# Altium schematic sheet SensorMotherBoard.SchDoc(SensorMotherBoard)
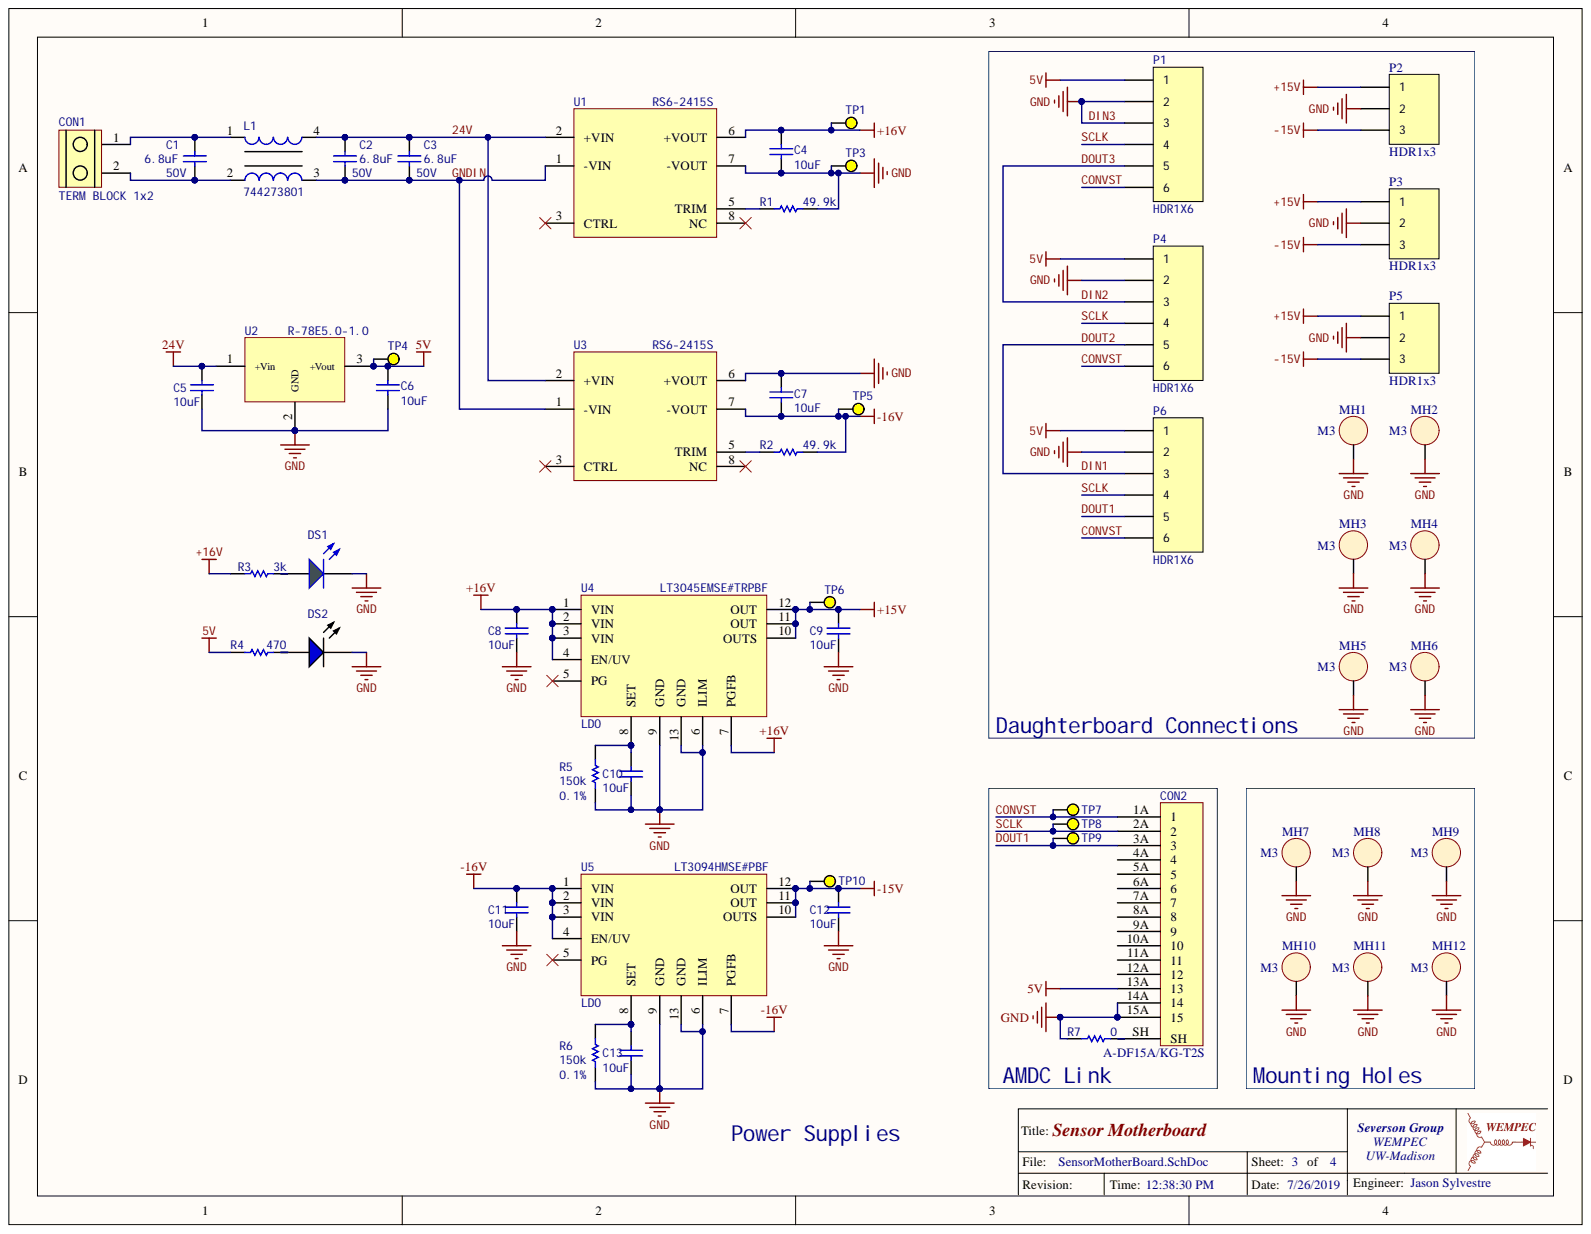
[diagram p0: SensorMotherBoard.SchDoc]

## Parts
*?  TitleBlockWEMPEC  (value not drawn)
C1  Voltage Rating (DC): 50V  Capacitance: 6.8uF  [CAP-1206]  (hidden comment: Cap)
C2  Voltage Rating (DC): 50V  Capacitance: 6.8uF  [CAP-1206]  (hidden comment: Cap)
C3  Voltage Rating (DC): 50V  Capacitance: 6.8uF  [CAP-1206]  (hidden comment: Cap)
C4  Capacitance: 10uF  [CAP-0805]  (hidden comment: Cap)
C5  Capacitance: 10uF  [CAP-0805]  (hidden comment: Cap)
C6  Capacitance: 10uF  [CAP-0805]  (hidden comment: Cap)
C7  Capacitance: 10uF  [CAP-0805]  (hidden comment: Cap)
C8  Capacitance: 10uF  [CAP-0805]  (hidden comment: Cap)
C9  Capacitance: 10uF  [CAP-0805]  (hidden comment: Cap)
C10  Capacitance: 10uF  [CAP-0805]  (hidden comment: Cap)
C11  Capacitance: 10uF  [CAP-0805]  (hidden comment: Cap)
C12  Capacitance: 10uF  [CAP-0805]  (hidden comment: Cap)
C13  Capacitance: 10uF  [CAP-0805]  (hidden comment: Cap)
CON1  TERM BLOCK 1x2  [1935161]
CON2  Manufacturer Part Number: A-DF15A/KG-T2S  Current Rating: 3A  [A-DF 15 A/KG-T2S]  (hidden comment: CON - A-DF 15 A/KG-T2S)
DS1  LTST-C193KGKT-5A  [LTST-C193KGKT-5A_V]  (value not drawn)
DS2  LTST-C193TBKT-5A  [LTST-C193TBKT_V]  (value not drawn)
L1  744273801  [WE-SL5-HC]
MH1  M3  [MOUNTING HOLE - M3]
MH2  M3  [MOUNTING HOLE - M3]
MH3  M3  [MOUNTING HOLE - M3]
MH4  M3  [MOUNTING HOLE - M3]
MH5  M3  [MOUNTING HOLE - M3]
MH6  M3  [MOUNTING HOLE - M3]
MH7  M3  [MOUNTING HOLE - M3]
MH8  M3  [MOUNTING HOLE - M3]
MH9  M3  [MOUNTING HOLE - M3]
MH10  M3  [MOUNTING HOLE - M3]
MH11  M3  [MOUNTING HOLE - M3]
MH12  M3  [MOUNTING HOLE - M3]
P1  HDR1X6  [HDR1x6 - MALE]
P2  HDR1x3  [HDR1x3 - MALE]
P3  HDR1x3  [HDR1x3 - MALE]
P4  HDR1X6  [HDR1x6 - MALE]
P5  HDR1x3  [HDR1x3 - MALE]
P6  HDR1X6  [HDR1x6 - MALE]
R1  Resistance: 49.9k  [RESC1608X55X30ML15T15]  (hidden comment: ERJ-3EKF4992V)
R2  Resistance: 49.9k  [RESC1608X55X30ML15T15]  (hidden comment: ERJ-3EKF4992V)
R3  Resistance: 3k  [RESC1608X55X30LL15T15]  (hidden comment: ERJ-3GEYJ302V)
R4  RC0603FR-07470RL  [RESC1608X55X25LL10T15]  (value not drawn)
R5  Tolerance: 0.1%  Resistance: 150k  [RESC1608X55X30LL20T20]  (hidden comment: ERA3AEB154V)
R6  Tolerance: 0.1%  Resistance: 150k  [RESC1608X55X30LL20T20]  (hidden comment: ERA3AEB154V)
R7  ERJ-3GEY0R00V  [RESC1608X55X30NL15T15]  (value not drawn)
TP1  5004  [KSTN-5004]  (value not drawn)
TP3  5004  [KSTN-5004]  (value not drawn)
TP4  5004  [KSTN-5004]  (value not drawn)
TP5  5004  [KSTN-5004]  (value not drawn)
TP6  5004  [KSTN-5004]  (value not drawn)
TP7  5004  [KSTN-5004]  (value not drawn)
TP8  5004  [KSTN-5004]  (value not drawn)
TP9  5004  [KSTN-5004]  (value not drawn)
TP10  5004  [KSTN-5004]  (value not drawn)
U1  Manufacturer Part Number: RS6-2415S  [RS6 DCDC]  (hidden comment: DCDC Converter RS6)
U2  DCDC - R-78E-1.0  [R-78E5.0-1.0]  (value not drawn)
U3  Manufacturer Part Number: RS6-2415S  [RS6 DCDC]  (hidden comment: DCDC Converter RS6)
U4  LDO  Manufacturer Part Number: LT3045EMSE#TRPBF  [MSOP-12]
U5  LDO  Manufacturer Part Number: LT3094HMSE#PBF  [MSOP-12]

## Nets
5V: C6.2 CON2.13A P1.1 P4.1 P6.1 R4.1 TP4.1 U2.3
+15V: C9.2 P2.1 P3.1 P5.1 TP6.1 U4.10(OUTS) U4.11(OUT) U4.12(OUT)
+16V: C4.2 C8.2 R3.1 TP1.1 U1.6(+VOUT) U4.1(VIN) U4.2(VIN) U4.3(VIN) U4.4(EN/UV) U4.7(PGFB)
-15V: C12.2 P2.3 P3.3 P5.3 TP10.1 U5.10(OUTS) U5.11(OUT) U5.12(OUT)
-16V: C7.1 C11.2 R2.2 TP5.1 U3.7(-VOUT) U5.1(VIN) U5.2(VIN) U5.3(VIN) U5.4(EN/UV) U5.7(PGFB)
24V: C2.2 C3.2 C5.2 L1.4 U1.2(+VIN) U2.1 U3.2(+VIN)
CONVST: CON2.1A P1.6 P4.6 P6.6 TP7.1
DIN1: P4.5 P6.3
DIN2: P1.5 P4.3
DIN3: C4.1 C5.1 C6.1 C7.2 C8.1 C9.1 C10.1 C11.1 C12.1 C13.1 CON2.14A CON2.15A DS1.2 DS2.2 MH1.1 MH2.1 MH3.1 MH4.1 MH5.1 MH6.1 MH7.1 MH8.1 MH9.1 MH10.1 MH11.1 MH12.1 P1.2 P1.3 P2.2 P3.2 P4.2 P5.2 P6.2 R1.2 R5.1 R6.1 R7.1 TP3.1 U1.7(-VOUT) U2.2 U3.6(+VOUT) U4.6(ILIM) U4.9(GND) U4.13(GND) U5.6(ILIM) U5.9(GND) U5.13(GND)
DOUT1: CON2.3A P6.5 TP9.1
GNDIN: C2.1 C3.1 L1.3 U1.1(-VIN) U3.1(-VIN)
SCLK: CON2.2A P1.4 P4.4 P6.4 TP8.1
NetC1_1: C1.1 CON1.2 L1.2
NetC1_2: C1.2 CON1.1 L1.1
NetC10_2: C10.2 R5.2 U4.8(SET)
NetC13_2: C13.2 R6.2 U5.8(SET)
NetCON2_SH: CON2.SH R7.2
NetDS1_1: DS1.1 R3.2
NetDS2_1: DS2.1 R4.2
NetR1_1: R1.1 U1.5(TRIM)
NetR2_1: R2.1 U3.5(TRIM)

Not connected: CON2 4A 5A 6A 7A 8A 9A 10A 11A 12A; U1 3(CTRL) 8(NC); U3 3(CTRL) 8(NC); U4 5(PG); U5 5(PG)

## Library data of the parts
C1-C3 = Cap: Capacitor; Manufacturer Part Number: C3216X5R1H685K160AB; Manufacturer: TDK; Tolerance: 10%; Dielectric Material: Ceramic; Dielectric: X5R; Width: 1.6mm; Weight: 0.000571oz; Voltage Rating: 50V; Thickness: 0.071inch; Termination: SMD/SMT; Supplier Part Number 1: 445-5996-1-ND; Supplier 1: Digi-Key; Stock 1: 8989; RoHS: Yes; REACH SVHC: No SVHC; Pricing 1: 1=1.18000, 10=0.89700, 100=0.64170, 500=0.49680, 1000=0.42780 (USD); Packaging: Tape and Reel; Package Quantity: 1; Mount: Surface Mount; Min Operating Temperature: -55°C; Max Operating Temperature: 85°C; Library Name: SensorCard.SchLib; Length: 3.2mm; Lead Free: Lead Free; Height: 1.6mm; Depth: 1.6mm; Composition: Ceramic; ComponentLink2URL: https://datasheet.ciiva.com/6253/tdkmlcccaprange-6253149.pdf?src-supplier=Digi-Key; ComponentLink2Description: https://datasheet.ciiva.com/6253/tdkmlcccaprange-6253149.pdf?src-supplier=Digi-Key; ComponentLink1URL: https://datasheet.ciiva.com/24217/c3216x5r1h685k160ab-265286-24217008.pdf?src-supplier=Mouser; ComponentLink1Description: https://datasheet.ciiva.com/24217/c3216x5r1h685k160ab-265286-24217008.pdf?src-supplier=Mouser; Component Kind: Standard; Case Code (Metric): 3216; Case Code (Imperial): 1206; Case/Package: 1206
C4-C13 = Cap: Capacitor; Manufacturer Part Number: CL21A106KAFN3NE; Manufacturer: Samsung; Voltage Rating: 25V; Tolerance: 10%; Width: 0.049inch; Thickness: 0.053inch; Supplier Part Number 1: 1276-2890-1-ND; Supplier 1: Digi-Key; Stock 1: 51388; RoHS: Yes; Pricing 1: 1=0.28000, 10=0.19300, 100=0.09770, 500=0.06684, 1000=0.05912 (USD); Packaging: Cut Tape; Package Quantity: 1; Mount: Surface Mount; Min Operating Temperature: -55°C; Max Operating Temperature: 85°C; Library Name: SensorCard.SchLib; Length: 0.079inch; Lead Free: Lead Free; ComponentLink1URL: https://datasheet.ciiva.com/25720/cl21a106kafn3ne-25720275.pdf?src-supplier=Digi-Key; ComponentLink1Description: https://datasheet.ciiva.com/25720/cl21a106kafn3ne-25720275.pdf?src-supplier=Digi-Key; Component Kind: Standard; Case Code (Metric): 2012; Case Code (Imperial): 0805; Case/Package: 0805
CON2 = CON - A-DF 15 A/KG-T2S: CONN D-SUB RCPT 15POS R/A SOLDER; Manufacturer: Assmann; Voltage Rating: 250V; Number of Positions: 15; Number of Ports: 1; Termination: Solder; Supplier Part Number 1: AE10929-ND; Supplier 1: Digi-Key; Stock 1: 0; RoHS: Yes; Radiation Hardening: No; Packaging: Tray; Orientation: Right Angle; Number of Terminals: 15; Number of Rows: 2; Number of Pins: 15; Mount: Through Hole; Min Operating Temperature: -55°C; Max Supply Voltage (AC): 250V; Max Operating Temperature: 105°C; Library Name: SensorCard.SchLib; Length: 39.2mm; Housing Material: PBT; Depth: 18.6mm; Contact Plating: Gold; Contact Material: Brass; Connector Type: D-Sub; ComponentLink1URL: https://datasheet.ciiva.com/26927/getdatasheetpartid-755447-26927443.pdf?src-supplier=Verical; ComponentLink1Description: https://datasheet.ciiva.com/26927/getdatasheetpartid-755447-26927443.pdf?src-supplier=Verical; Component Kind: Standard; Color: Black
DS1 = CMP-2000-06622-1: LED Green, 75 mW, 80 mA, -55 to 85 degC, 2-Pin SMD, RoHS, Tape and Reel; Manufacturer: Lite-On; Viewing Angle: 130°; Test Current: 5mA; Reverse Voltage: 5V; Power Rating: 75mW; Number of LEDs: 1; Luminous Intensity: 28mcd; Lens Type: Clear; Lens Style: Diffused; Lens Color: Colorless; Forward Voltage: 2.3V; Forward Current: 30mA; Dominant Wavelength: 576.5nm; Width: 0.8mm; Supplier Part Number 1: 160-1828-1-ND; Supplier 1: Digi-Key; Stock 1: 98599; RoHS: Yes; Radiation Hardening: No; Pricing 1: 1=0.41000, 10=0.29600, 25=0.22680, 100=0.14800, 250=0.10852, 500=0.09210, 1000=0.07565, 2500=0.06578 (USD); Power Dissipation: 75mW; Packaging: Tape and Reel; Package Reference: C193KGKT-5A; Package Quantity: 3000; Package Description: 2-Pin Surface Mount Device, Body 1.6 x 0.8 mm; Number of Pins: 2; Number of Elements: 1; Mounting Technology: SurfaceMount; Mount: Surface Mount; Min Operating Temperature: -55°C; Max Operating Temperature: 85°C; Manufacturer URL: http://www.us.liteon.com/; Manufacturer Part Number: LTST-C193KGKT-5A; Library Name: Altium Content Vault; Lens Transparency: Clear; Length: 1.6mm; Lead Free: Lead Free; Intensity: 28mcd; Illumination Color: Green; Height: 0.35mm; Forward (Drive) Current: 5mA; Dimension: 1.6x0.8x0.35mm; Depth: 0.8mm; Datasheet Version: 03/2007; Datasheet URL: http://optoelectronics.liteon.com/upload/download/DS22-2007-0094/S_110_LTST-C193KGKT-5A.pdf; ComponentLink6URL: https://datasheet.ciiva.com/2110/s-110-ltst-c193kgkt-5a-2110268.pdf?src-supplier=Digi-Key; ComponentLink6Description: https://datasheet.ciiva.com/2110/s-110-ltst-c193kgkt-5a-2110268.pdf?src-supplier=Digi-Key; ComponentLink5URL: https://datasheet.ciiva.com/2110/s-110-ltst-c193kgkt-5a-2110269.pdf?src-supplier=Digi-Key; ComponentLink5Description: https://datasheet.ciiva.com/2110/s-110-ltst-c193kgkt-5a-2110269.pdf?src-supplier=Digi-Key; ComponentLink4URL: https://datasheet.ciiva.com/2110/s-110-ltst-c193kgkt-5a-2110267.pdf?src-supplier=Digi-Key; ComponentLink4Description: https://datasheet.ciiva.com/2110/s-110-ltst-c193kgkt-5a-2110267.pdf?src-supplier=Digi-Key; ComponentLink3URL: https://datasheet.ciiva.com/16444/ltst-c193kgkt-5a-16444759.pdf?src-supplier=Future+Electronics; ComponentLink3Description: https://datasheet.ciiva.com/16444/ltst-c193kgkt-5a-16444759.pdf?src-supplier=Future+Electronics; ComponentLink2URL: https://datasheet.ciiva.com/11773/0900766b80dc0550-11773612.pdf?src-supplier=RSComponents; ComponentLink2Description: https://datasheet.ciiva.com/11773/0900766b80dc0550-11773612.pdf?src-supplier=RSComponents; ComponentLink1URL: https://datasheet.ciiva.com/12400/ltst-c193-series-201756-12400795.pdf?src-supplier=Mouser; ComponentLink1Description: https://datasheet.ciiva.com/12400/ltst-c193-series-201756-12400795.pdf?src-supplier=Mouser; Component Kind: Standard; Color: Green; Case Code (Metric): 1608; Case Code (Imperial): 0603; Case/Package: 0603
DS2 = CMP-2000-06624-1: LED Uni-Color Blue, 76 mW, 20 mA, -20 to 80 degC, 2-Pin SMD, RoHS, Tape and Reel; Manufacturer: Lite-On; Wavelength/Color Temperature: 465nmto475nm; Viewing Angle: 130deg; Test Current: 5mA; Reverse Voltage: 5V; Power Dissipation: 76mW; Number of LEDs: 1; Luminous Intensity: 15mcd; Lens Transparency: Clear; Lens Style: Diffused; Lens Color: Colorless; LED Size: 1.6mmx0.8mmx0.35mm; Forward Voltage: 2.8V; Dominant Wavelength: 475nm; Width: 0.8mm; Supplier Part Number 1: 160-1827-1-ND; Supplier 1: Digi-Key; Stock 1: 234360; RoHS: Details; Radiation Hardening: No; Product Category: StandardLEDs-SMD; Pricing 1: 1=0.47000, 10=0.33600, 25=0.25720, 100=0.16780, 250=0.12304, 500=0.10442, 1000=0.08577, 2500=0.07458 (USD); Packaging: Reel; Package Reference: C193TBKT; Package Quantity: 1; Package Description: 2-Pin Surface Mount Device, Body 0.8 x 1.6 mm; Package / Case: 0603; Number of Pins: 2; Number of Elements: 1; Mounting Technology: SurfaceMount; Mount: Surface Mount; Min Operating Temperature: -20°C; Minimum Operating Temperature: -20C; Max Operating Temperature: 80°C; Maximum Operating Temperature: +80C; Manufacturer URL: http://www.us.liteon.com/; Manufacturer Part Number: LTST-C193TBKT-5A; Library Name: Altium Content Vault; Length: 1.6mm; Lead Free: Lead Free; Illumination Color: Blue; Height: 0.35mm; Forward Current: 5mA; Factory Pack Quantity: 3000; Depth: 0.8mm; Datasheet Version: 08/2011; Datasheet URL: https://datasheet.ciiva.com/15201/getdatasheetpartid-293056-15201395.pdf; ComponentLink7URL: https://datasheet.ciiva.com/2111/p-100-ltst-c193tbkt-5a-2111221.pdf?src-supplier=Digi-Key; ComponentLink7Description: https://datasheet.ciiva.com/2111/p-100-ltst-c193tbkt-5a-2111221.pdf?src-supplier=Digi-Key; ComponentLink6URL: https://datasheet.ciiva.com/2111/p-100-ltst-c193tbkt-5a-2111220.pdf?src-supplier=Digi-Key; ComponentLink6Description: https://datasheet.ciiva.com/2111/p-100-ltst-c193tbkt-5a-2111220.pdf?src-supplier=Digi-Key; ComponentLink5URL: https://datasheet.ciiva.com/16210/ltst-c193tbkt-5a-16210824.pdf?src-supplier=Future+Electronics; ComponentLink5Description: https://datasheet.ciiva.com/16210/ltst-c193tbkt-5a-16210824.pdf?src-supplier=Future+Electronics; ComponentLink4URL: https://datasheet.ciiva.com/11773/0900766b80dc0554-11773880.pdf?src-supplier=RSComponents; ComponentLink4Description: https://datasheet.ciiva.com/11773/0900766b80dc0554-11773880.pdf?src-supplier=RSComponents; ComponentLink3URL: https://datasheet.ciiva.com/15201/getdatasheetpartid-293056-15201395.pdf?src-supplier=Verical; ComponentLink3Description: https://datasheet.ciiva.com/15201/getdatasheetpartid-293056-15201395.pdf?src-supplier=Verical; ComponentLink2URL: https://datasheet.ciiva.com/2111/ltst-c193tbkt-5a-2111219.pdf?src-supplier=Digi-Key; ComponentLink2Description: https://datasheet.ciiva.com/2111/ltst-c193tbkt-5a-2111219.pdf?src-supplier=Digi-Key; ComponentLink1URL: https://datasheet.ciiva.com/4688/lite-on-ltst-c193tbkt-5a-187386-4688832.pdf?src-supplier=Mouser; ComponentLink1Description: https://datasheet.ciiva.com/4688/lite-on-ltst-c193tbkt-5a-187386-4688832.pdf?src-supplier=Mouser; Component Kind: Standard; Color: Blue; Case Code (Metric): 1608; Case Code (Imperial): 0603; Case/Package: 0603; Brand: Lite-On
L1 = CMP-0222-00003-1: SMD Common Mode Line Filter WE-SL5 HC, L = 9.00 µH; Manufacturer: Wurth Electronics; Voltage Rating (DC): 80V; Tolerance: 25%; Test Frequency: 100kHz; Series Resistance: 11mR; Number of Lines: 2; Max DC Current: 3.5A; Inductance: 9.00 ÂµH; Impedance: 800R; Core Material: Ferrite; Width: 8.3mm; Termination: SMD/SMT; Supplier Part Number 1: 732-2215-6-ND; Supplier 1: Digi-Key; Stock 1: 4132; RoHS: Yes; Resistance: 11mR; REACH SVHC: No SVHC; Pricing 1: 1=3.37000, 10=3.12500, 50=2.82200, 100=2.72200, 500=2.52000 (USD); Packaging: Tape and Reel; PackageVersion: 2010; PackageReference: WE-SL5-HC; Package Quantity: 1000; PackageDescription: SMD Inductor, Body 8.3 x 9.5 mm; Number of Pins: 4; Mount: Surface Mount; Min Operating Temperature: -40°C; Max Operating Temperature: 125°C; Manufacturer Part Number: 744273801; Library Name: Altium Content Vault; Length: 9.5mm; Inductance Tolerance: 25%; Height: 5.3mm; Depth: 8.3mm; DC Resistance (DCR): 11mR; DatasheetVersion: ver 3, Feb-2010; Current Rating: 3.5A; Current: 3.5A; ComponentLink10URL: https://datasheet.ciiva.com/48690/001087412-da-01-ml-we-sl5-hc-stromk-smd-lin-fil-74427-de-en-48690507.pdf?src-supplier=Conrad; ComponentLink10Description: https://datasheet.ciiva.com/48690/001087412-da-01-ml-we-sl5-hc-stromk-smd-lin-fil-74427-de-en-48690507.pdf?src-supplier=Conrad; ComponentLink9URL: https://datasheet.ciiva.com/14123/744273801-eng-tds-14123645.pdf?src-supplier=Distrelec; ComponentLink9Description: https://datasheet.ciiva.com/14123/744273801-eng-tds-14123645.pdf?src-supplier=Distrelec; ComponentLink8URL: https://datasheet.ciiva.com/7764/1735681-7764966.pdf?src-supplier=Element14; ComponentLink8Description: https://datasheet.ciiva.com/7764/1735681-7764966.pdf?src-supplier=Element14; ComponentLink7URL: https://datasheet.ciiva.com/6215/744273801-6215157.pdf?src-supplier=Digi-Key; ComponentLink7Description: https://datasheet.ciiva.com/6215/744273801-6215157.pdf?src-supplier=Digi-Key; ComponentLink6URL: https://datasheet.ciiva.com/4952/744273801-77788-4952319.pdf?src-supplier=Mouser; ComponentLink6Description: https://datasheet.ciiva.com/4952/744273801-77788-4952319.pdf?src-supplier=Mouser; ComponentLink5URL: https://datasheet.ciiva.com/11800/0900766b80f886be-11800844.pdf?src-supplier=RSComponents; ComponentLink5Description: https://datasheet.ciiva.com/11800/0900766b80f886be-11800844.pdf?src-supplier=RSComponents; ComponentLink4URL: https://datasheet.ciiva.com/6048/1735681-6048514.pdf?src-supplier=Farnell; ComponentLink4Description: https://datasheet.ciiva.com/6048/1735681-6048514.pdf?src-supplier=Farnell; ComponentLink3URL: https://datasheet.ciiva.com/6215/744273801-6215152.pdf?src-supplier=Digi-Key; ComponentLink3Description: https://datasheet.ciiva.com/6215/744273801-6215152.pdf?src-supplier=Digi-Key; ComponentLink2URL: http://www.we-online.de/katalog/media/pdf/744273801.pdf; ComponentLink2Description: Datasheet; ComponentLink1URL: http://www.we-online.com; ComponentLink1Description: Manufacturer Link; Component Kind: Standard; Case/Package: SMD/SMT
MH1-MH12 = MOUNT_HOLE: MOUNTING HOLE; Library Name: SensorCard.SchLib; Component Kind: Standard
P1 P4 P6 = HDR1X6: Manufacturer Part Number: 61300611121; Manufacturer: Wurth Electronics; Voltage Rating: 250V; Pitch: 0.1inch; Number of Positions: 6; Gender: Male; Current Rating: 3A; Connector Type: Male Pin; Termination: Through Hole; Supplier Part Number 1: 732-5319-ND; Supplier 1: Digi-Key; Stock 1: 9604; RoHS: Yes; REACH SVHC: Unknown; Pricing 1: 1=0.33000, 10=0.27900, 25=0.23200, 50=0.19400, 100=0.17600, 1000=0.16000 (USD); Packaging: Bulk; Number of Rows: 1; Number of Contacts: 6; Mount: Through Hole; Min Operating Temperature: -40°C; Max Operating Temperature: 125°C; Library Name: SensorCard.SchLib; Lead Free: Lead Free; Housing Material: PBT; Contact Plating: Gold; Contact Mating Length: 0.236inch; Contact Material: Copper Alloy; Contact Gender: Male; ComponentLink5URL: http://www.farnell.com/cad/1737268.pdf?src-supplier=Element14; ComponentLink5Description: http://www.farnell.com/cad/1737268.pdf?src-supplier=Element14; ComponentLink4URL: https://katalog.we-online.de/em/datasheet/6130xx11121.pdf?src-supplier=Digi-Key; ComponentLink4Description: https://katalog.we-online.de/em/datasheet/6130xx11121.pdf?src-supplier=Digi-Key; ComponentLink3URL: http://www.mouser.com/ds/2/445/6130xx11121-538006.pdf?src-supplier=Mouser; ComponentLink3Description: http://www.mouser.com/ds/2/445/6130xx11121-538006.pdf?src-supplier=Mouser; ComponentLink2URL: https://datasheet.ciiva.com/43513/2152996-43513418.pdf?src-supplier=Onlinecomponents.com; ComponentLink2Description: https://datasheet.ciiva.com/43513/2152996-43513418.pdf?src-supplier=Onlinecomponents.com; ComponentLink1URL: https://datasheet.ciiva.com/29369/001094900-da-01-en-buchsenleiste-1-reihig-0-0215299-6-29369971.pdf?src-supplier=Conrad; ComponentLink1Description: https://datasheet.ciiva.com/29369/001094900-da-01-en-buchsenleiste-1-reihig-0-0215299-6-29369971.pdf?src-supplier=Conrad; Component Kind: Standard; Color: Black
P2 P3 P5 = HDR1x3: Manufacturer Part Number: 61300311121; Manufacturer: Wurth Electronics; Pitch: 0.1inch; Number of Positions: 3; Gender: Male; Connector Type: Male Pin; Termination: Solder; Supplier Part Number 1: 732-5316-ND; Supplier 1: Digi-Key; Stock 1: 113049; RoHS: Yes; REACH SVHC: Unknown; Pricing 1: 1=0.13000, 10=0.10600, 25=0.08800, 50=0.07400, 100=0.06700, 1000=0.06100 (USD); Packaging: Bulk; Number of Rows: 1; Number of Contacts: 3; Mount: Through Hole; Library Name: SensorCard.SchLib; Lead Free: Lead Free; Contact Plating: Gold; Contact Mating Length: 0.236inch; Contact Material: Copper; ComponentLink2URL: https://katalog.we-online.de/em/datasheet/6130xx11121.pdf?src-supplier=Digi-Key; ComponentLink2Description: https://katalog.we-online.de/em/datasheet/6130xx11121.pdf?src-supplier=Digi-Key; ComponentLink1URL: http://www.farnell.com/cad/1737268.pdf?src-supplier=Element14; ComponentLink1Description: http://www.farnell.com/cad/1737268.pdf?src-supplier=Element14; Component Kind: Standard; Color: Black
R1 R2 = CMP-2000-00536-1: Manufacturer Part Number: ERJ3EKF4992V; Manufacturer: Panasonic; Voltage Rating (DC): 50V; Voltage Rating: 75V; Tolerance: 1%; Temperature Coefficient: 100ppm/°C; Power Rating: 100mW; Width: 0.8mm; Weight: 7.1E-05oz; Termination: SMD/SMT; Supplier Part Number 1: P49.9KHCT-ND; Supplier 1: Digi-Key; Stock 1: 354572; RoHS: Yes; REACH SVHC: No SVHC; Radiation Hardening: No; Pricing 1: 1=0.10000, 10=0.06500, 100=0.02640, 1000=0.01186, 2500=0.01029 (USD); Part Number: ERJ-3EKF4992V; Packaging: Tape and Reel; Package Quantity: 1; Number of Terminations: 2; Mount: Surface Mount; Min Operating Temperature: -55°C; Military Standard: Not; Max Power Dissipation: 100mW; Max Operating Temperature: 155°C; Library Name: Altium Content Vault; Length: 1.6mm; Lead Free: Lead Free; Height: 0.45mm; Features: Automotive AEC-Q200; Depth: 0.8mm; Contact Plating: Tin; Composition: Thick Film; ComponentLink10URL: https://datasheet.ciiva.com/30147/aoa0000ce2-30147900.pdf?src-supplier=Onlinecomponents.com; ComponentLink10Description: https://datasheet.ciiva.com/30147/aoa0000ce2-30147900.pdf?src-supplier=Onlinecomponents.com; ComponentLink9URL: https://datasheet.ciiva.com/27055/1988209-27055691.pdf?src-supplier=Jameco; ComponentLink9Description: https://datasheet.ciiva.com/27055/1988209-27055691.pdf?src-supplier=Jameco; ComponentLink8URL: https://datasheet.ciiva.com/15159/getdatasheetpartid-52039-15159595.pdf?src-supplier=Verical; ComponentLink8Description: https://datasheet.ciiva.com/15159/getdatasheetpartid-52039-15159595.pdf?src-supplier=Verical; ComponentLink7URL: https://datasheet.ciiva.com/28694/1678782-28694218.pdf?src-supplier=Element14; ComponentLink7Description: https://datasheet.ciiva.com/28694/1678782-28694218.pdf?src-supplier=Element14; ComponentLink6URL: https://datasheet.ciiva.com/48531/aoa0000ce2-48531016.pdf?src-supplier=Avnet; ComponentLink6Description: https://datasheet.ciiva.com/48531/aoa0000ce2-48531016.pdf?src-supplier=Avnet; ComponentLink5URL: https://datasheet.ciiva.com/6064/426256-6064568.pdf?src-supplier=Farnell; ComponentLink5Description: https://datasheet.ciiva.com/6064/426256-6064568.pdf?src-supplier=Farnell; ComponentLink4URL: https://datasheet.ciiva.com/17209/aoa0000ce2-17209495.pdf?src-supplier=Avnet+Europe; ComponentLink4Description: https://datasheet.ciiva.com/17209/aoa0000ce2-17209495.pdf?src-supplier=Avnet+Europe; ComponentLink3URL: https://datasheet.ciiva.com/16440/aoa0000ce2-16440183.pdf?src-supplier=Future+Electronics; ComponentLink3Description: https://datasheet.ciiva.com/16440/aoa0000ce2-16440183.pdf?src-supplier=Future+Electronics; ComponentLink2URL: https://datasheet.ciiva.com/46377/426256-46377973.pdf?src-supplier=Element14; ComponentLink2Description: https://datasheet.ciiva.com/46377/426256-46377973.pdf?src-supplier=Element14; ComponentLink1URL: https://datasheet.ciiva.com/43460/426256-43460597.pdf?src-supplier=Farnell; ComponentLink1Description: https://datasheet.ciiva.com/43460/426256-43460597.pdf?src-supplier=Farnell; Component Kind: Standard; Case Code (Metric): 1608; Case Code (Imperial): 0603; Case/Package: 0603
R3 = CMP-2000-00767-1: Manufacturer Part Number: ERJ3GEYJ302V; Manufacturer: Panasonic; Voltage Rating: 75V; Tolerance: 5%; Temperature Coefficient: 200ppm/°C; Power Rating: 100mW; Width: 0.031inch; Termination: SMD/SMT; Supplier Part Number 1: P3.0KGCT-ND; Supplier 1: Digi-Key; Stock 1: 269975; RoHS: Yes; REACH SVHC: No SVHC; Pricing 1: 1=0.10000, 10=0.04300, 100=0.01760, 1000=0.00791, 2500=0.00686 (USD); Part Number: ERJ-3GEYJ302V; Packaging: Tape and Reel; Package Quantity: 1; Number of Terminations: 2; Mount: Surface Mount; Min Operating Temperature: -55°C; Military Standard: Not; Max Power Dissipation: 100mW; Max Operating Temperature: 155°C; Library Name: Altium Content Vault; Length: 1.6mm; Height: 0.45mm; Features: Automotive AEC-Q200; Depth: 0.8mm; Contact Plating: Tin; Composition: Thick Film; ComponentLink10URL: https://datasheet.ciiva.com/28691/1678796-28691966.pdf?src-supplier=Element14; ComponentLink10Description: https://datasheet.ciiva.com/28691/1678796-28691966.pdf?src-supplier=Element14; ComponentLink9URL: https://datasheet.ciiva.com/28565/1678796-28565150.pdf?src-supplier=Element14; ComponentLink9Description: https://datasheet.ciiva.com/28565/1678796-28565150.pdf?src-supplier=Element14; ComponentLink8URL: https://datasheet.ciiva.com/30196/4aoa0000ce1-30196300.pdf?src-supplier=Onlinecomponents.com; ComponentLink8Description: https://datasheet.ciiva.com/30196/4aoa0000ce1-30196300.pdf?src-supplier=Onlinecomponents.com; ComponentLink7URL: https://datasheet.ciiva.com/46386/1500035-46386731.pdf?src-supplier=Element14; ComponentLink7Description: https://datasheet.ciiva.com/46386/1500035-46386731.pdf?src-supplier=Element14; ComponentLink6URL: https://datasheet.ciiva.com/9196/aoa0000ce1-9196746.pdf?src-supplier=Avnet; ComponentLink6Description: https://datasheet.ciiva.com/9196/aoa0000ce1-9196746.pdf?src-supplier=Avnet; ComponentLink5URL: https://datasheet.ciiva.com/16786/70255313-16786823.pdf?src-supplier=Allied+Electronics; ComponentLink5Description: https://datasheet.ciiva.com/16786/70255313-16786823.pdf?src-supplier=Allied+Electronics; ComponentLink4URL: https://datasheet.ciiva.com/9196/aoa0000ce1-9196743.pdf?src-supplier=Avnet; ComponentLink4Description: https://datasheet.ciiva.com/9196/aoa0000ce1-9196743.pdf?src-supplier=Avnet; ComponentLink3URL: https://datasheet.ciiva.com/43460/1500035-43460725.pdf?src-supplier=Farnell; ComponentLink3Description: https://datasheet.ciiva.com/43460/1500035-43460725.pdf?src-supplier=Farnell; ComponentLink2URL: https://datasheet.ciiva.com/24027/aoa0000ce1-37422-24027397.pdf?src-supplier=Mouser; ComponentLink2Description: https://datasheet.ciiva.com/24027/aoa0000ce1-37422-24027397.pdf?src-supplier=Mouser; ComponentLink1URL: https://datasheet.ciiva.com/11821/0900766b80f806dd-11821475.pdf?src-supplier=RSComponents; ComponentLink1Description: https://datasheet.ciiva.com/11821/0900766b80f806dd-11821475.pdf?src-supplier=RSComponents; Component Kind: Standard; Case Code (Metric): 1608; Case Code (Imperial): 0603; Case/Package: 0603
R4 = CMP-1659-00035-1: General Purpose Chip Resistor, 470 Ohm, +/- 1%, -55 to 155 degC, 0603 (1608 Metric), RoHS, Tape and Reel; Manufacturer: Yageo; Working Voltage: 75V; Voltage Rating: 50V; Temperature Coefficient: 100ppm/°C; Resistance: 470; Power Rating: 100mW; Width: 0.031inch; Tolerance: 1%; Termination: SMD/SMT; Supplier Part Number 1: 311-470HRCT-ND; Supplier 1: Digi-Key; Stock 1: 7151461; RoHS: Yes; REACH SVHC: No SVHC; Pricing 1: 1=0.10000, 10=0.02400, 100=0.00970, 1000=0.00437, 2500=0.00379 (USD); Packaging: Tape and Reel; Package Quantity: 1; Number of Terminations: 2; Min Operating Temperature: -55°C; Military Standard: Not; Max Power Dissipation: 100mW; Max Operating Temperature: 155°C; Manufacturer Part Number: RC0603FR-07470RL; Library Name: Altium Content Vault; Length: 1.6mm; Lead Free: Lead Free; Height: 0.022inch; Features: Moisture Resistant; Depth: 0.8mm; Composition: Thick Film; ComponentLink10URL: https://datasheet.ciiva.com/2454/pyu-r-int-thick-7-2454014.pdf?src-supplier=Digi-Key; ComponentLink10Description: https://datasheet.ciiva.com/2454/pyu-r-int-thick-7-2454014.pdf?src-supplier=Digi-Key; ComponentLink9URL: https://datasheet.ciiva.com/2454/pyu-r-int-thick-7-2454013.pdf?src-supplier=Digi-Key; ComponentLink9Description: https://datasheet.ciiva.com/2454/pyu-r-int-thick-7-2454013.pdf?src-supplier=Digi-Key; ComponentLink8URL: https://datasheet.ciiva.com/2454/pyu-r-int-thick-7-2454012.pdf?src-supplier=Digi-Key; ComponentLink8Description: https://datasheet.ciiva.com/2454/pyu-r-int-thick-7-2454012.pdf?src-supplier=Digi-Key; ComponentLink7URL: https://datasheet.ciiva.com/46440/1868787-46440907.pdf?src-supplier=Element14; ComponentLink7Description: https://datasheet.ciiva.com/46440/1868787-46440907.pdf?src-supplier=Element14; ComponentLink6URL: https://datasheet.ciiva.com/7660/1868787-7660793.pdf?src-supplier=Element14; ComponentLink6Description: https://datasheet.ciiva.com/7660/1868787-7660793.pdf?src-supplier=Element14; ComponentLink5URL: https://datasheet.ciiva.com/7746/1738695-7746360.pdf?src-supplier=Element14; ComponentLink5Description: https://datasheet.ciiva.com/7746/1738695-7746360.pdf?src-supplier=Element14; ComponentLink4URL: https://datasheet.ciiva.com/7746/1738695-7746359.pdf?src-supplier=Element14; ComponentLink4Description: https://datasheet.ciiva.com/7746/1738695-7746359.pdf?src-supplier=Element14; ComponentLink3URL: https://datasheet.ciiva.com/11808/0900766b80f96399-11808177.pdf?src-supplier=RSComponents; ComponentLink3Description: https://datasheet.ciiva.com/11808/0900766b80f96399-11808177.pdf?src-supplier=RSComponents; ComponentLink2URL: https://datasheet.ciiva.com/11808/0900766b80f96399-11808177.pdf; ComponentLink2Description: Datasheet; ComponentLink1URL: http://www.yageo.com/; ComponentLink1Description: Manufacturer URL; Component Kind: Standard; Case Code (Metric): 1608; Case Code (Imperial): 0603; Case/Package: 0603
R5 R6 = CMP-2000-00918-1: Manufacturer: Panasonic; Voltage Rating (DC): 75V; Temperature Coefficient: 25ppm/°C; Power Rating: 100mW; Width: 0.8mm; Voltage Rating: 75V; Termination: SMD/SMT; Supplier Part Number 1: P150KDBCT-ND; Supplier 1: Digi-Key; Stock 1: 238168; RoHS: Yes; REACH SVHC: No SVHC; Radiation Hardening: No; Pricing 1: 1=0.35000, 10=0.30000, 100=0.11720, 1000=0.04906, 2500=0.04498 (USD); Part Number: ERA3AEB154V; Packaging: Tape and Reel; Package Quantity: 1; Number of Terminations: 2; Number of Pins: 2; Mount: Surface Mount; Min Operating Temperature: -55°C; Military Standard: Not; Max Power Dissipation: 100mW; Max Operating Temperature: 155°C; Manufacturer Part Number: ERA3AEB154V; Library Name: Altium Content Vault; Length: 1.6mm; Lead Free: Lead Free; Height: 0.45mm; Features: Automotive AEC-Q200; Depth: 0.8mm; Contact Plating: Tin; Composition: Metal Film; ComponentLink15URL: https://datasheet.ciiva.com/28691/485442-28691903.pdf?src-supplier=Element14; ComponentLink15Description: https://datasheet.ciiva.com/28691/485442-28691903.pdf?src-supplier=Element14; ComponentLink14URL: https://datasheet.ciiva.com/16770/70068849-16770767.pdf?src-supplier=Allied+Electronics; ComponentLink14Description: https://datasheet.ciiva.com/16770/70068849-16770767.pdf?src-supplier=Allied+Electronics; ComponentLink13URL: https://datasheet.ciiva.com/16212/aoa0000ce26-16212095.pdf?src-supplier=Future+Electronics; ComponentLink13Description: https://datasheet.ciiva.com/16212/aoa0000ce26-16212095.pdf?src-supplier=Future+Electronics; ComponentLink12URL: https://datasheet.ciiva.com/43455/485442-43455245.pdf?src-supplier=Farnell; ComponentLink12Description: https://datasheet.ciiva.com/43455/485442-43455245.pdf?src-supplier=Farnell; ComponentLink11URL: https://datasheet.ciiva.com/9117/aoa0000ce26-9117373.pdf?src-supplier=Avnet; ComponentLink11Description: https://datasheet.ciiva.com/9117/aoa0000ce26-9117373.pdf?src-supplier=Avnet; ComponentLink10URL: https://datasheet.ciiva.com/36857/485442-36857163.pdf?src-supplier=Element14; ComponentLink10Description: https://datasheet.ciiva.com/36857/485442-36857163.pdf?src-supplier=Element14; ComponentLink9URL: https://datasheet.ciiva.com/17207/aoa0000ce26-17207467.pdf?src-supplier=Avnet+Europe; ComponentLink9Description: https://datasheet.ciiva.com/17207/aoa0000ce26-17207467.pdf?src-supplier=Avnet+Europe; ComponentLink8URL: https://datasheet.ciiva.com/6001/485442-6001675.pdf?src-supplier=Farnell; ComponentLink8Description: https://datasheet.ciiva.com/6001/485442-6001675.pdf?src-supplier=Farnell; ComponentLink7URL: https://datasheet.ciiva.com/6001/485442-6001674.pdf?src-supplier=Farnell; ComponentLink7Description: https://datasheet.ciiva.com/6001/485442-6001674.pdf?src-supplier=Farnell; ComponentLink6URL: https://datasheet.ciiva.com/30175/54aoa0000ce26-30175385.pdf?src-supplier=Onlinecomponents.com; ComponentLink6Description: https://datasheet.ciiva.com/30175/54aoa0000ce26-30175385.pdf?src-supplier=Onlinecomponents.com; ComponentLink5URL: https://datasheet.ciiva.com/16767/70068849-16767065.pdf?src-supplier=Allied+Electronics; ComponentLink5Description: https://datasheet.ciiva.com/16767/70068849-16767065.pdf?src-supplier=Allied+Electronics; ComponentLink4URL: https://datasheet.ciiva.com/9117/aoa0000ce26-9117369.pdf?src-supplier=Avnet; ComponentLink4Description: https://datasheet.ciiva.com/9117/aoa0000ce26-9117369.pdf?src-supplier=Avnet; ComponentLink3URL: https://datasheet.ciiva.com/24070/aoa0000ce26-197354-24070709.pdf?src-supplier=Mouser; ComponentLink3Description: https://datasheet.ciiva.com/24070/aoa0000ce26-197354-24070709.pdf?src-supplier=Mouser; ComponentLink2URL: https://datasheet.ciiva.com/29183/451718-da-01-en-widerstand-0603-era-29183878.pdf?src-supplier=Conrad; ComponentLink2Description: https://datasheet.ciiva.com/29183/451718-da-01-en-widerstand-0603-era-29183878.pdf?src-supplier=Conrad; ComponentLink1URL: https://datasheet.ciiva.com/11808/0900766b80fe20f7-11808025.pdf?src-supplier=RSComponents; ComponentLink1Description: https://datasheet.ciiva.com/11808/0900766b80fe20f7-11808025.pdf?src-supplier=RSComponents; Component Kind: Standard; Case Code (Metric): 1608; Case Code (Imperial): 0603; Case/Package: 0603
R7 = CMP-2000-00700-1: Manufacturer: Panasonic; Voltage Rating (DC): 50V; Voltage Rating: 75V; Tolerance: 0%; Temperature Coefficient: 600ppm/°C; Resistance: 0; Power Rating: 100mW; Width: 0.031inch; Termination: SMD/SMT; Supplier Part Number 1: P0.0GCT-ND; Supplier 1: Digi-Key; Stock 1: 31571560; RoHS: Yes; REACH SVHC: No SVHC; Radiation Hardening: No; Pricing 1: 1=0.10000, 10=0.04200, 25=0.03040, 50=0.02320, 100=0.01710, 250=0.01308, 500=0.01046, 1000=0.00770 (USD); Part Number: ERJ-3GEY0R00V; Packaging: Tape and Reel; Package Quantity: 1; Number of Terminations: 2; Mount: Surface Mount; Min Operating Temperature: -55°C; Military Standard: Not; Max Power Dissipation: 100mW; Max Operating Temperature: 155°C; Manufacturer Part Number: ERJ-3GEY0R00V; Library Name: Altium Content Vault; Length: 1.6mm; Lead Free: Lead Free; Height: 0.45mm; Features: Automotive AEC-Q200; Depth: 0.8mm; Contact Plating: Tin; Composition: Thick Film; ComponentLink12URL: https://datasheet.ciiva.com/9198/aoa0000ce1-9198975.pdf?src-supplier=Avnet; ComponentLink12Description: https://datasheet.ciiva.com/9198/aoa0000ce1-9198975.pdf?src-supplier=Avnet; ComponentLink11URL: https://datasheet.ciiva.com/17215/aoa0000ce1-17215388.pdf?src-supplier=Avnet+Europe; ComponentLink11Description: https://datasheet.ciiva.com/17215/aoa0000ce1-17215388.pdf?src-supplier=Avnet+Europe; ComponentLink10URL: https://datasheet.ciiva.com/16834/aoa0000ce1-16834828.pdf?src-supplier=Future+Electronics; ComponentLink10Description: https://datasheet.ciiva.com/16834/aoa0000ce1-16834828.pdf?src-supplier=Future+Electronics; ComponentLink9URL: https://datasheet.ciiva.com/16758/70255313-16758527.pdf?src-supplier=Allied+Electronics; ComponentLink9Description: https://datasheet.ciiva.com/16758/70255313-16758527.pdf?src-supplier=Allied+Electronics; ComponentLink8URL: https://datasheet.ciiva.com/30199/4aoa0000ce1-30199822.pdf?src-supplier=Onlinecomponents.com; ComponentLink8Description: https://datasheet.ciiva.com/30199/4aoa0000ce1-30199822.pdf?src-supplier=Onlinecomponents.com; ComponentLink7URL: https://datasheet.ciiva.com/46377/1678796-46377868.pdf?src-supplier=Element14; ComponentLink7Description: https://datasheet.ciiva.com/46377/1678796-46377868.pdf?src-supplier=Element14; ComponentLink6URL: https://datasheet.ciiva.com/5959/1678796-5959707.pdf?src-supplier=Farnell; ComponentLink6Description: https://datasheet.ciiva.com/5959/1678796-5959707.pdf?src-supplier=Farnell; ComponentLink5URL: https://datasheet.ciiva.com/28695/1678796-28695833.pdf?src-supplier=Element14; ComponentLink5Description: https://datasheet.ciiva.com/28695/1678796-28695833.pdf?src-supplier=Element14; ComponentLink4URL: https://datasheet.ciiva.com/43460/1678796-43460647.pdf?src-supplier=Farnell; ComponentLink4Description: https://datasheet.ciiva.com/43460/1678796-43460647.pdf?src-supplier=Farnell; ComponentLink3URL: https://datasheet.ciiva.com/48532/aoa0000ce1-48532165.pdf?src-supplier=Avnet; ComponentLink3Description: https://datasheet.ciiva.com/48532/aoa0000ce1-48532165.pdf?src-supplier=Avnet; ComponentLink2URL: https://datasheet.ciiva.com/27055/1935748-27055113.pdf?src-supplier=Jameco; ComponentLink2Description: https://datasheet.ciiva.com/27055/1935748-27055113.pdf?src-supplier=Jameco; ComponentLink1URL: https://datasheet.ciiva.com/11808/0900766b80f806dd-11808207.pdf?src-supplier=RSComponents; ComponentLink1Description: https://datasheet.ciiva.com/11808/0900766b80f806dd-11808207.pdf?src-supplier=RSComponents; Component Kind: Standard; Case Code (Metric): 1608; Case Code (Imperial): 0603; Case/Package: 0603
TP1 TP3-TP10 = CMP-2000-05575-1: Test Point, Yellow, 1-Pin THD, RoHS, Bulk; Manufacturer: Keystone; Plating: Silver; Outside Diameter: 2.54mm; Number of Ports: 1; Inside Diameter: 1.27mm; Body Material: PBT; Supplier Part Number 1: 36-5004-ND; Supplier 1: Digi-Key; Stock 1: 164959; RoHS: Yes; REACH SVHC: No SVHC; Pricing 1: 1=0.35000, 10=0.33500, 50=0.24380, 250=0.21032, 1000=0.17140, 2500=0.15296, 5000=0.14340 (USD); Packaging: Bulk; Package Reference: 5004; Package Quantity: 10; Package Description: 1-Pin Through Hole Device, Dia 2.54 mm; Orientation: Straight; Mounting Technology: ThroughHole; Mount: Through Hole; Material: Nylon; Manufacturer URL: http://www.keyelco.com/; Manufacturer Part Number: 5004; Library Name: Altium Content Vault; Length: 7.62mm; Lead Free: Lead Free; Housing Color: Yellow; Height: 0.3inch; Flammability Rating: UL94 V-0; Datasheet Version: Rev. G, 12/2008; Datasheet URL: http://www.keyelco.com/product-pdf.cfm?p=1313; Contact Plating: Silver; Contact Material: Bronze; ComponentLink3URL: https://datasheet.ciiva.com/1454/1703983-1454827.pdf?src-supplier=Element14; ComponentLink3Description: https://datasheet.ciiva.com/1454/1703983-1454827.pdf?src-supplier=Element14; ComponentLink2URL: https://datasheet.ciiva.com/16507/m60-2p60-16507925.pdf?src-supplier=Future+Electronics; ComponentLink2Description: https://datasheet.ciiva.com/16507/m60-2p60-16507925.pdf?src-supplier=Future+Electronics; ComponentLink1URL: https://datasheet.ciiva.com/2917/5000-5004-2917632.pdf?src-supplier=Digi-Key; ComponentLink1Description: https://datasheet.ciiva.com/2917/5000-5004-2917632.pdf?src-supplier=Digi-Key; Component Kind: Standard; Color: Yellow
U1 U3 = DCDC Converter RS6: Recom RS6; Max Output Voltage: 16.5V; Width: 9.2mm; Weight: 4g; Supplier Part Number 1: 945-2987-ND; Supplier 1: Digi-Key; Stock 1: 91; RoHS: No; Pricing 1: 1=14.62000, 5=14.50800, 22=14.39773, 44=14.17591, 66=13.73303, 110=12.40400, 264=12.18250, 506=11.96101 (USD); Manufacturer: Recom; Library Name: SensorCard.SchLib; Length: 21.8mm; Lead Free: Lead Free; Height: 11.1mm; ComponentLink1URL: https://www.recom-power.com/pdf/Econoline/RS6.pdf?src-supplier=Digi-Key; ComponentLink1Description: https://www.recom-power.com/pdf/Econoline/RS6.pdf?src-supplier=Digi-Key; Component Kind: Standard
U2 = DCDC - R-78E-1.0: Manufacturer: Recom; Voltage - Output 1: 5V; Output Power: 5W; Number of Outputs: 1; Min Input Voltage: 8V; Max Output Current: 1A; Max Input Voltage: 28V; Efficiency: 91%; Width: 8.5mm; Weight: 2g; Supplier Part Number 1: 945-2201-ND; Supplier 1: Digi-Key; Stock 1: 14983; RoHS: Yes; Pricing 1: 1=3.26000, 5=3.20800, 10=3.16200, 42=3.06905, 84=2.97595, 126=2.88302, 252=2.79000, 504=2.60401 (USD); Packaging: Rail/Tube; Package Quantity: 42; Mount: Through Hole; Min Operating Temperature: -40°C; Max Power Dissipation: 5W; Max Output Voltage: 5V; Max Operating Temperature: 85°C; Manufacturer Part Number: R-78E5.0-1.0; Library Name: SensorCard.SchLib; Length: 11.6mm; Lead Free: Lead Free; Height: 10.4mm; Features: SCP; Current - Output 1: 1A; ComponentLink4URL: https://www.recom-power.com/pdf/Innoline/R-78Exx-1.0.pdf?src-supplier=Digi-Key; ComponentLink4Description: https://www.recom-power.com/pdf/Innoline/R-78Exx-1.0.pdf?src-supplier=Digi-Key; ComponentLink3URL: http://www.farnell.com/datasheets/2290573.pdf?src-supplier=Newark; ComponentLink3Description: http://www.farnell.com/datasheets/2290573.pdf?src-supplier=Newark; ComponentLink2URL: http://www.mouser.com/ds/2/468/R-78Exx-1.0-958279.pdf?src-supplier=Mouser; ComponentLink2Description: http://www.mouser.com/ds/2/468/R-78Exx-1.0-958279.pdf?src-supplier=Mouser; ComponentLink1URL: http://www.farnell.com/datasheets/2290573.pdf?src-supplier=Farnell; ComponentLink1Description: http://www.farnell.com/datasheets/2290573.pdf?src-supplier=Farnell; Component Kind: Standard; Case/Package: SIP
U4 = LDO - LT3045: 20V, 500mA, Ultralow Noise, Ultrahigh PSRR Linear Regulator; Manufacturer: Analog Devices / Linear Technology; Supplier Part Number 1: LT3045EMSE#TRPBFCT-ND; Supplier 1: Digi-Key; Stock 1: 345; RoHS: No; Pricing 1: 1=7.14000, 25=4.74360, 100=3.92220, 250=3.72612, 500=3.64208 (USD); Library Name: SensorCard.SchLib; ComponentLink1URL: http://www.linear.com/docs/55649?src-supplier=Digi-Key; ComponentLink1Description: http://www.linear.com/docs/55649?src-supplier=Digi-Key; Component Kind: Standard; Case/Package: MSOP
U5 = LDO - LT3094: −20V, 500mA, Ultralow Noise, Ultrahigh PSRR Negative Linear Regulator; Manufacturer: Analog Devices; Supplier Part Number 1: LT3094HMSE#PBF-ND; Supplier 1: Digi-Key; Stock 1: 32; RoHS: No; Pricing 1: 1=8.72000, 25=5.79080, 100=4.78800, 250=4.54860, 500=4.44600 (USD); Library Name: SensorCard.SchLib; ComponentLink1URL: http://www.linear.com/docs/55649?src-supplier=Digi-Key; ComponentLink1Description: http://www.linear.com/docs/55649?src-supplier=Digi-Key; Component Kind: Standard
*? = TitleBlockWEMPEC: Library Name: SensorCard.SchLib; Component Kind: Standard
CON1 = TERM BLOCK 1x2: Supplier Part Number 1: 277-1667-ND; Supplier 1: Digi-Key; Library Name: SensorCard.SchLib; Component Kind: Standard
